# Altium schematic sheet Power.SchDoc
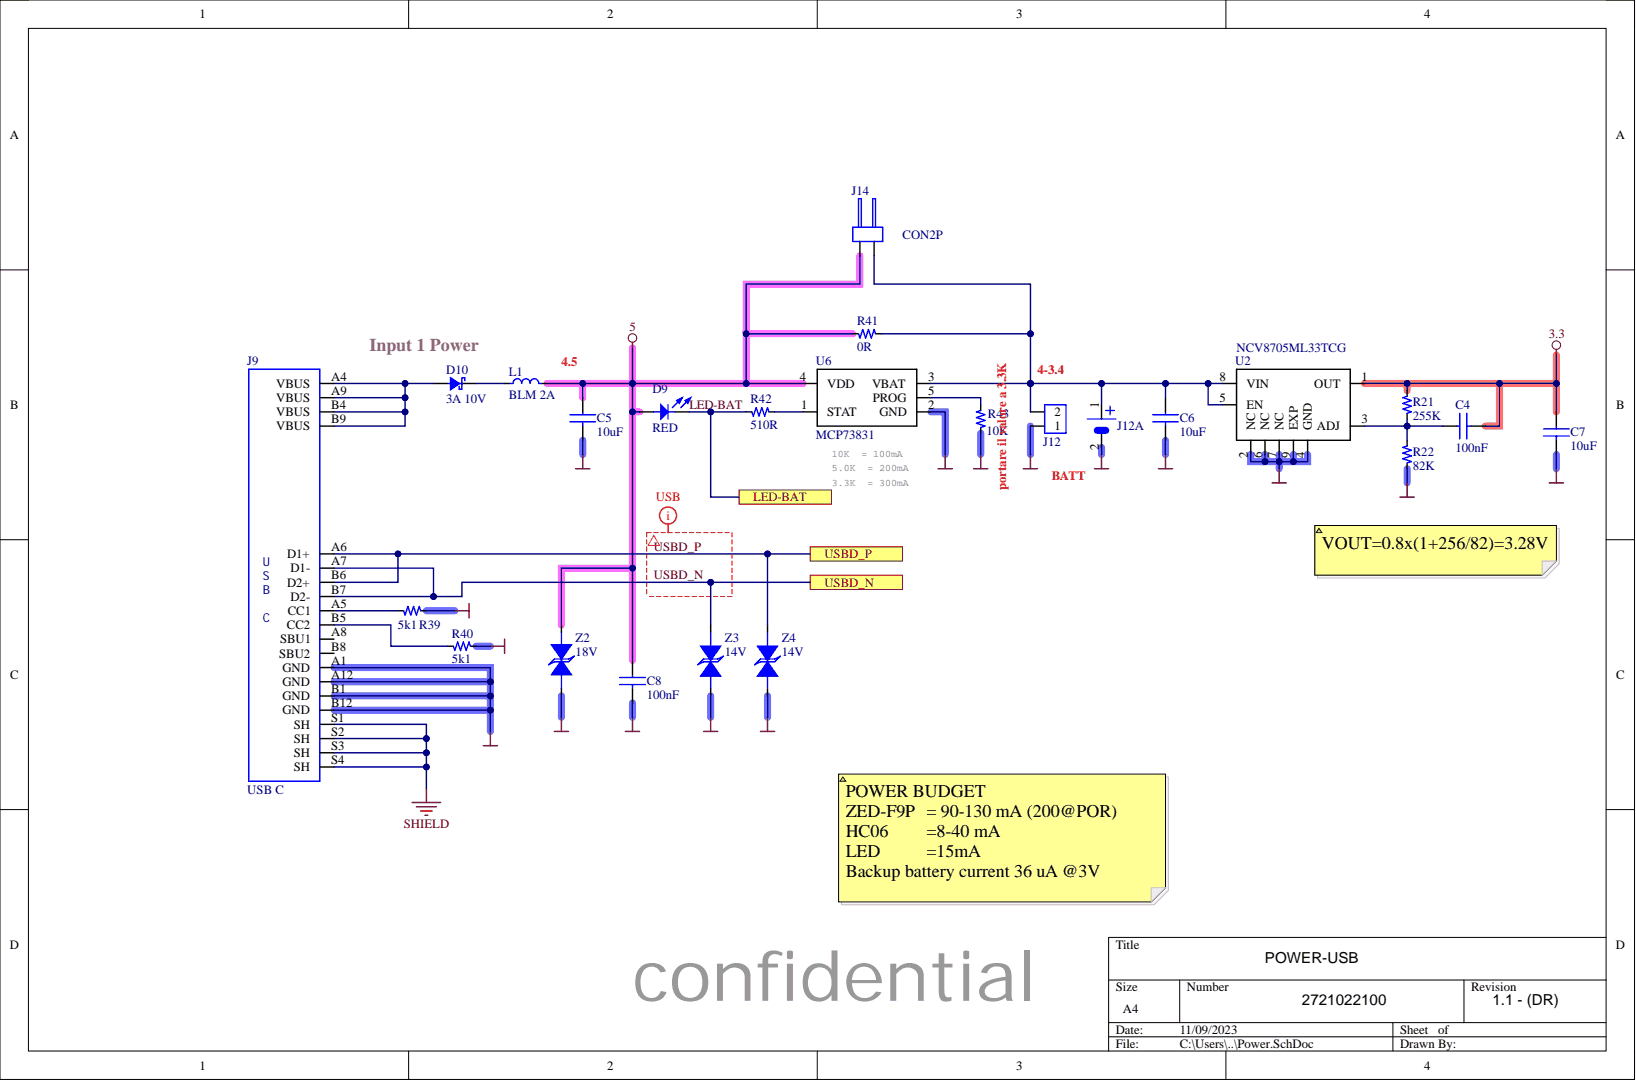
[diagram p0: Power.SchDoc]

## Parts
C4  100nF  [RC0402_1]
C5  10uF  [RC0603_1]
C6  10uF  [RC0603_1]
C7  10uF  [RC0603_1]
C8  100nF  [RC0603_1]
D9  RED  [LED_SMD_0603_RED]
D10  3A 10V  [SOD323]
J9  USB C  [CON_SMD_TYPEC_USB4105_GCT]
J12  2P  [CON_TH_2PIN_3.5P_691103110002_WE]  (value not drawn)
J12A  2P  [CON_SMD_2PIN_S2B-PH-SM4-TB(LF)(SN)_JST]  (value not drawn)
J14  CON2P  [CON_TH_2PIN_2.54P_825433-2 _TE]
L1  BLM 2A  [IND_SMD_BLM18(0603)_1]
R21  255K  [RC0603_1]
R22  82K  [RC0603_1]
R39  5k1  [RC0603_1]
R40  5k1  [RC0603_1]
R41  0R  [RC0603_1]
R42  510R  [RC0603_1]
R43  10K  [RC0603_1]
U2  NCV8705ML33TCG  [SON_8PIN_0.65P_3.15W_PAD]
U6  MCP73831  [SOT23_5PIN_0.95P_1.75W]
Z2  18V  [ESD_PESD0402-140]
Z3  14V  [ESD_PESD0402-140]
Z4  14V  [ESD_PESD0402-140]

## Ports
LED-BAT, USBD_N, USBD_P

## Nets
3.3: C4.2 C7.1 R21.1 U2.1(OUT)
5: C5.1 C8.1 D9.ANODE J14.1 L1.2 R41.1 U6.4(VDD) Z2.1
GND: C5.2 C6.2 C7.2 C8.2 J9.A1(GND) J9.A12(GND) J9.B1(GND) J9.B12(GND) J12.1 J12A.2 R22.2 R39.2 R40.1 R43.2 U2.2(NC) U2.4(GND) U2.6(NC) U2.7(NC) U2.9(EXP) U6.2(GND) Z2.2 Z3.1 Z4.1
SHIELD: J9.S1(SH) J9.S2(SH) J9.S3(SH) J9.S4(SH)
LED-BAT: D9.CATHODE R42.2 ; port LED-BAT
USBD_N: J9.A7(D1-) J9.B7(D2-) Z3.2 ; port USBD_N
USBD_P: J9.A6(D1+) J9.B6(D2+) Z4.2 ; port USBD_P
NetC4_1: C4.1 R21.2 R22.1 U2.3(ADJ)
NetC6_1: C6.1 J12.2 J12A.1 J14.2 R41.2 U2.5(EN) U2.8(VIN) U6.3(VBAT)
NetD10_ANODE: D10.ANODE J9.A4(VBUS) J9.A9(VBUS) J9.B4(VBUS) J9.B9(VBUS)
NetD10_CATHODE: D10.CATHODE L1.1
NetJ9_A5: J9.A5(CC1) R39.1
NetJ9_B5: J9.B5(CC2) R40.2
NetR42_1: R42.1 U6.1(STAT)
NetR43_1: R43.1 U6.5(PROG)

Not connected: J9 A8(SBU1) B8(SBU2)

## Library data of the parts
C4 = GRM155R71C104KA88D: CAP, CERAMICA, 100NF, 16V, X7R, 0402; Manufacturer: MURATA; Order c: 8819742; upBCE: 0.01; Value: 0.1UF; Case: 0402; Component Kind: Standard; Library Name: mylibA.SchLib; Manufacturer n: GRM155R71C104KA88D
C5-C7 = GRM188R61A106ME69D: CAP, MLCC, X5R, 10UF, 10V, X5R, 0603; Manufacturer: MURATA; Order c: 81-GRM188R61A106ME9D; upBCE: 0.03; Case: 0603; Component Kind: Standard; Library Name: mylibA.SchLib; Manufacturer n: GRM188R61A106ME69D
C8 = GRM188R71H104KA93D: CAP, CERAMICA, 100NF, 50V, X7R, 10%, -55+125C°, 0603; Manufacturer: MURATA; Order c: 81-GRM188R71H104KA93; upBCE: 0.0018; Value: 0.1UF; Case: 0603; Component Kind: Standard; Library Name: mylibA.SchLib; Manufacturer n: GRM188R71H104KA93; Mouser: 81-GRM188R71H104KA93
D9 = LED_ RED_0603_1: LED, 0603, RED, 15MCD, 625NM; Manufacturer: KINGBRIGHT; Manufacturer n: KPT-1608EC; Order c: 2099221; Case: 0603; Component Kind: Standard; Library Name: mylibA.SchLib; Value: RED
D10 = BAT60JFILM: DIODO, SCHOTTKY, 3A, 10V, SMD; Manufacturer: ST; Order c: 1467544; Case: SOD-323; Component Kind: Standard; Library Name: mylibA.SchLib; Manufacturer n: BAT60JFILM; Value: 3A 10V
J9 = USB_TYPE_C_16PINS_SMD_GCT-USB4105-GF-A-120: MICRO USB TYPE C, SMT Horizontal; Manufacturer: GCT; Order c: 640-USB4105-GF-A-120; upBCE: 0.389; Component Kind: Standard; Library Name: mylibA.SchLib; Manufacturer n: USB4105-GF-A-120
J12 = HEADER_PCB_2P_2.5_WURTH_H_SCREW: MORSETTIERA, PCB, 3.5MM, 2 VIE, 16 AMPER; Manufacturer: WURTH; Manufacturer n: 691103110002; Order c: 1841248; upBCE: 0.46; Component Kind: Standard; Library Name: mylibA.SchLib
J12A = BATTERY_LITIO_CONNECTOR_S2B-PH-SM4-TB(LF)(SN): Connector Header, PH Series, 2 Position, Side Entry, 2.00mm, Solder, Surface Mount; Manufacturer: JST; Order c: 455-1749-1-ND; Component Kind: Standard; Library Name: mylibA.SchLib; Manufacturer n: S2B-PH-SM4-TB(LF)(SN)
J14 = HEADER_2.54_PINSTRIP_BIPHASE: HEADER, P2.54, H6.7MM, BIFASE; Manufacturer: TE; Manufacturer n: 825433-2; Order c: 3417645; Component Kind: Standard; Library Name: mylibA.SchLib
L1 = BLM18EG101TN1D: FERRITE, BEAD,  0603, 100OHM, 2A; Manufacturer: MURATA; Order c: 81-BLM18EG101TN1D; upBCE: 0.064; Case: 0603; Component Kind: Standard; Library Name: mylibA.SchLib; Manufacturer n: BLM18EG101TN1D; Value: BLM 2A
R21 = CRCW0603255KFKEAC: RESISTORE, 255K, 0.1W, 1%, 0603, SMD; Manufacturer: Vishay Dralogic; Order c: 71-CRCW0603255KFKEAC; upBCE: 0.00066; Case: 0603; Component Kind: Standard; Library Name: mylibA.SchLib; Manufacturer n: CRCW0603255KFKEAC; Value: 255K
R22 = CRCW060382K0FKEAC: RESISTORE, 82K, 0.1W, 1%, 0603, SMD; Manufacturer: Vishay Dralogic; Order c: 71-CRCW060382K0FKEAC; upBCE: 0.00066; Case: 0603; Component Kind: Standard; Library Name: mylibA.SchLib; Manufacturer n: CRCW060382K0FKEAC; Value: 82K
R39 R40 = CRCW06035K10FKEB: RESISTORE, 5K1, 0.1W, 1%, 0603, SMD; Manufacturer: Vishay Dralogic; Order c: 71-CRCW06035K10FKEB; upBCE: 0.00066; Case: 0603; Component Kind: Standard; Library Name: mylibA.SchLib; Manufacturer n: CRCW06035K10FKEB; Value: 5k1
R41 = CRCW06030000Z0EA: RESISTORE, 0R, 0.1W, 1%, 0603, SMD; Manufacturer: Vishay Dralogic; Order c: 1469739; upBCE: 0.00066; Case: 0603; Component Kind: Standard; Library Name: mylibA.SchLib; Manufacturer n: CRCW06030000Z0EA; Value: 0R
R42 = CRCW0603510RFKEA: RESISTORE, 510R, 0.1W, 1%, 0603, SMD; Manufacturer: Vishay Dralogic; Order c: 1469826; Case: 0603; Component Kind: Standard; Library Name: mylibA.SchLib; Manufacturer n: CRCW0603510RFKEA; Value: 510R
R43 = CRCW060310K0FKEA: RESISTORE, 10K, 0.1W, 1%, 0603, SMD; Manufacturer: Vishay Dralogic; Order c: 1469748; upBCE: 0.00066; Case: 0603; Component Kind: Standard; Library Name: mylibA.SchLib; Manufacturer n: CRCW060310K0FKEA; Value: 10K
U2 = REGULATOR_LDO_LOWNOISE_NCV8705ML33TCG: IC, V REG, LDO 2.5-5.5 Vin,3.3V, DFNW8, 500mA; Manufacturer: ON; Order c: 3368462; upBCE: 0.529; Case: SMD; Component Kind: Standard; Library Name: mylibA.SchLib; Manufacturer n: NCV8705ML33TCG; Value: NCV8705ML33TCG
U6 = LIPO_CHARGE_MCP73831T-2ACI/OT: Miniature Single-Cell, Fully Integrated Li-Ion,  Li-Polymer Charge Management Controllers; Manufacturer: MICROCHIP; Brand: Microchip Technology; Operating Supply Voltage: 3.75 V to 6 V; Order c: 579-MCP73831T-2ACIOT; Output Current: 15 mA to 500 mA; Output Voltage: 4.2 V; upSingle: 0.51; Battery Type: Li-Ion, Li-Polymer; Case: SOT-23-5; Category: Battery Management; Component Kind: Standard; ComponentLink1Description: http://www.mouser.com/ds/2/268/20001984g-846362.pdf; ComponentLink1URL: http://www.mouser.com/ds/2/268/20001984g-846362.pdf; Height: 1.3 mm; Length: 3.1 mm; Library Name: mylibA.SchLib; Manufacturer n: MCP73831T-2ACI/OT; Manufacturer Part Number: MCP73831T-2ACI/OT; Maximum Operating Temperature: + 85 C; Minimum Operating Temperature: - 40 C; Minimum Order Quantity: 1; Mounting Style: SMD/SMT; Package/Case: SOT-23; Packaging: Reel; Pricing 1: 1=0.510, 10=0.476, 25=0.400, 100=0.357, 3000=0.357 (EUR); Reeling: True; RoHS: RoHS Compliant; RoHS - Mouser: Y; Series: MCP73831/2; Standard Pack Qty: 3000; Stock 1: 52342; Supplier 1: Mouser; Type: Charge Controller; Value: MCP73831; Width: 1.8 mm
Z2 = ESD_0402_CG0402MLE-18G: TVS Diodes - 9pF, 18V; Manufacturer: BOURNS; BCE price: 0.027; Order c: 2846102; Case: SMD; Component Kind: Standard; Library Name: mylibA.SchLib; Manufacturer n: CG0402MLE-18G; Value: 18V
Z3 Z4 = ESD_0402_PESD0402-140: TVS Diodes - 0.25pF, 12V, 3.4Ghz; Manufacturer: Littelfuse; BCE price: 0.138; Order c: 650-PESD0402-140; Case: SMD; Component Kind: Standard; Library Name: mylibA.SchLib; Manufacturer n: PESD0402-140; Value: 14V
